# Altium schematic sheet input_filter.SchDoc(input_filter)
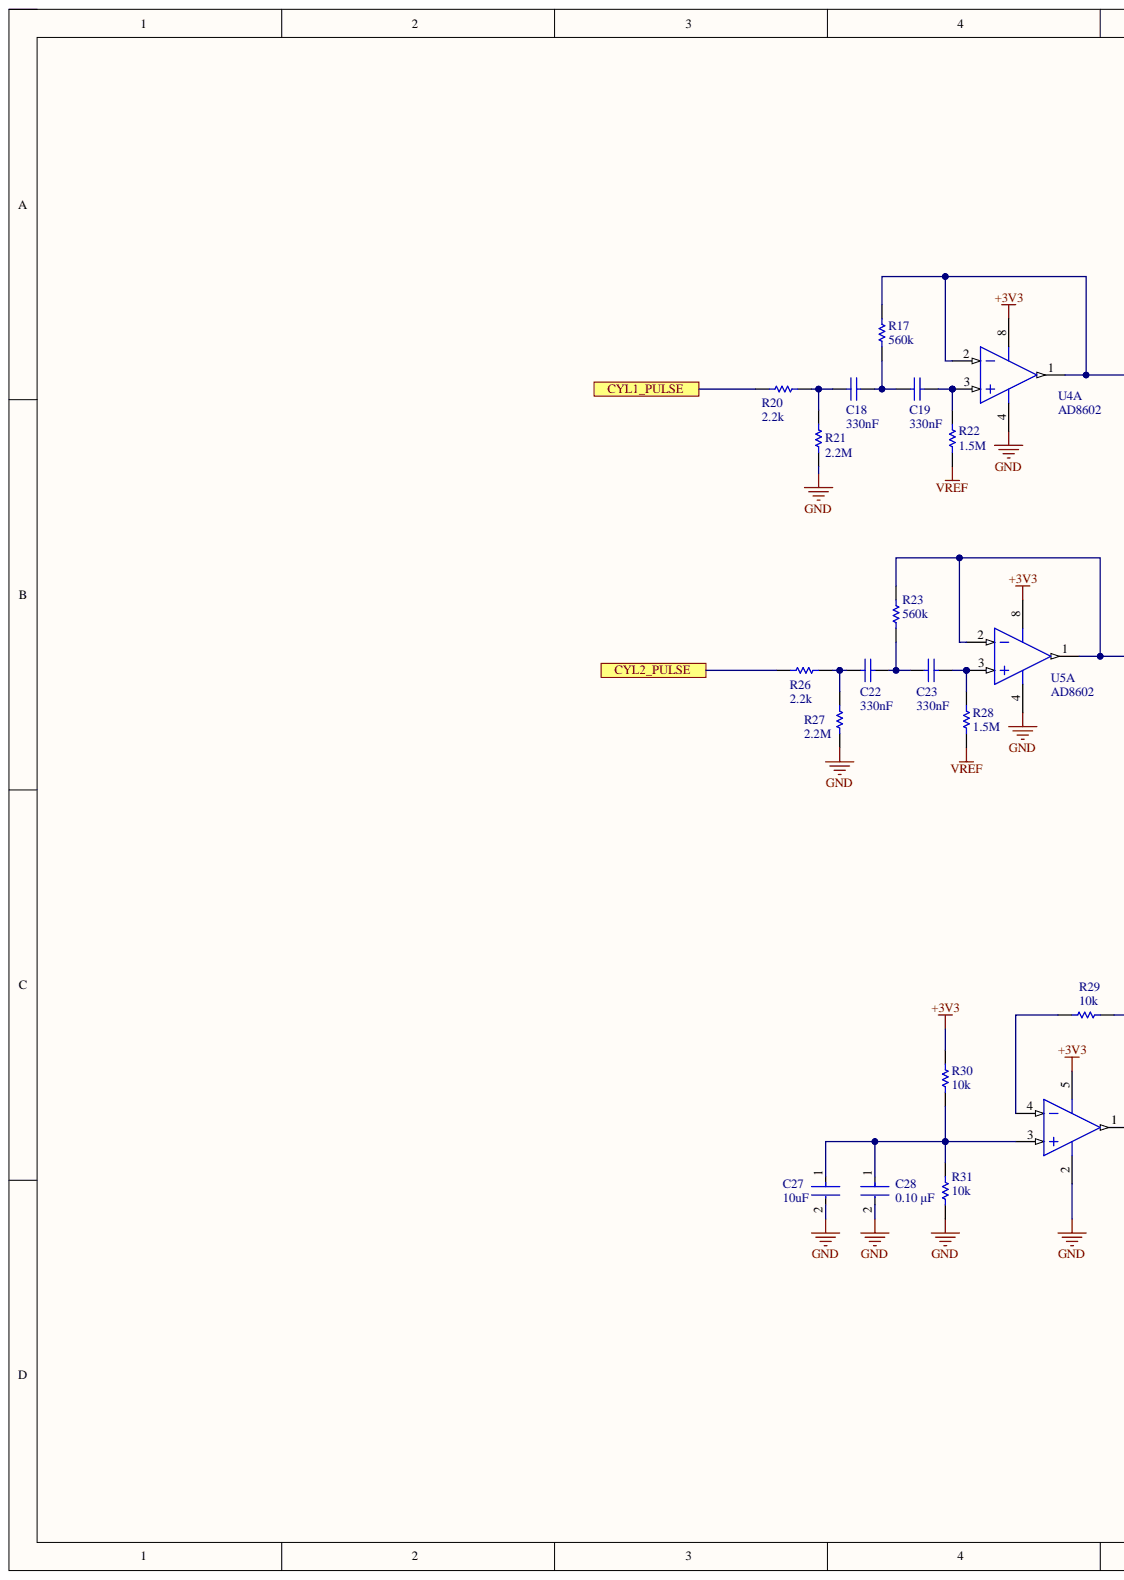
[diagram p0: input_filter.SchDoc, left]
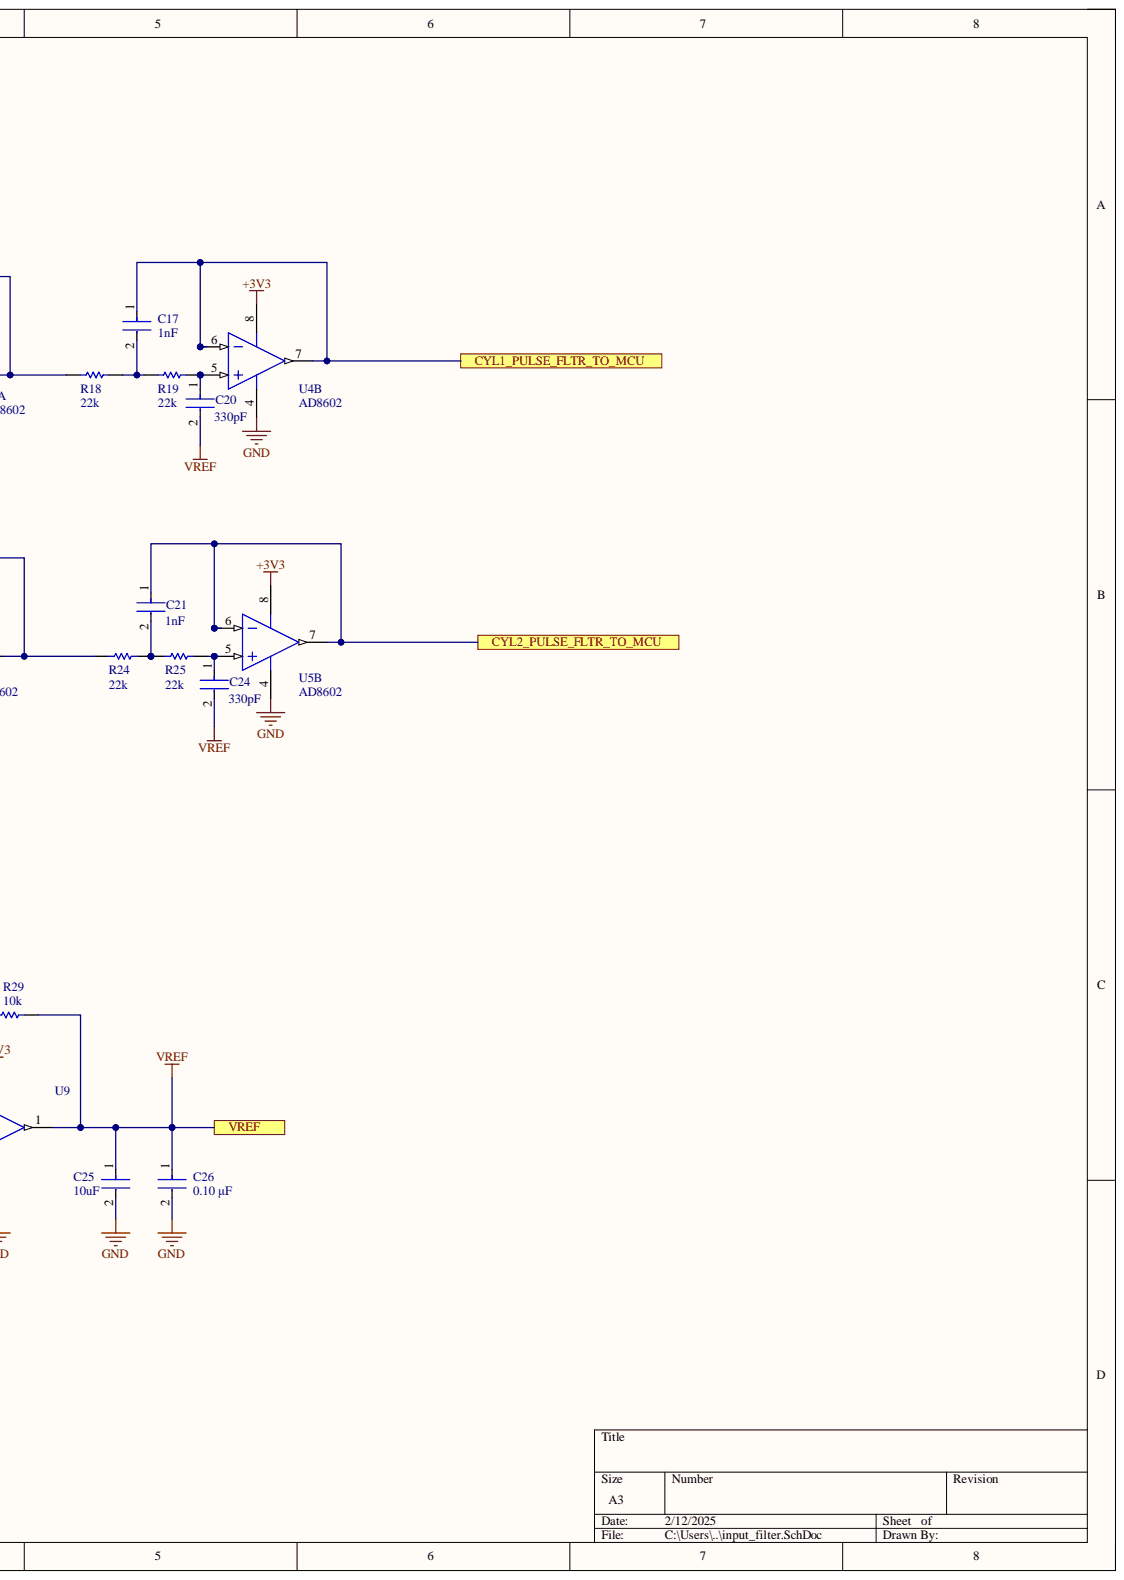
[diagram p1: input_filter.SchDoc, right]

## Parts
C17  Capacitance: 1nF  [FP-GRM155-0_05-IPC_B]  (hidden comment: GRM1555C1H102JA01D)
C18  value 330nF  [CAPC1005X55X25ML05T10]  (hidden comment: GRM155R61A334KE15D)
C19  value 330nF  [CAPC1005X55X25ML05T10]  (hidden comment: GRM155R61A334KE15D)
C20  Capacitance: 330pF  [FP-GRM155-0_05-MFG]  (hidden comment: GRM1555C1H331JA01D)
C21  Capacitance: 1nF  [FP-GRM155-0_05-IPC_B]  (hidden comment: GRM1555C1H102JA01D)
C22  value 330nF  [CAPC1005X55X25ML05T10]  (hidden comment: GRM155R61A334KE15D)
C23  value 330nF  [CAPC1005X55X25ML05T10]  (hidden comment: GRM155R61A334KE15D)
C24  Capacitance: 330pF  [FP-GRM155-0_05-MFG]  (hidden comment: GRM1555C1H331JA01D)
C25  value 10uF  Capacitance: 10uF  [FP-CL05A106MP5NUNC-MFG]  (hidden comment: CL05A106MP5NUNC)
C26  value 0.10 μF  Capacitance: 0.10 μF  [FP-C0402-BB-MFG]  (hidden comment: C0402C104K8PACTU)
C27  value 10uF  Capacitance: 10uF  [FP-CL05A106MP5NUNC-MFG]  (hidden comment: CL05A106MP5NUNC)
C28  value 0.10 μF  Capacitance: 0.10 μF  [FP-C0402-BB-MFG]  (hidden comment: C0402C104K8PACTU)
R17  value 560k  [RESC1005X40X25LL05T10]  (hidden comment: RC0402FR-07560KL)
R18  value 22k  [RESC1005X40X25NL05T10]  (hidden comment: RC0402FR-0722KL)
R19  value 22k  [RESC1005X40X25NL05T10]  (hidden comment: RC0402FR-0722KL)
R20  value 2.2k  [RESC1005X40X25NL05T10]  (hidden comment: RC0402FR-0722KL)
R21  value 2.2M  [RESC1005X40X25ML05T10]  (hidden comment: RC0402FR-072M2L)
R22  value 1.5M  [RESC1005X40X25NL05T10]  (hidden comment: RC0402FR-071M5L)
R23  value 560k  [RESC1005X40X25LL05T10]  (hidden comment: RC0402FR-07560KL)
R24  value 22k  [RESC1005X40X25NL05T10]  (hidden comment: RC0402FR-0722KL)
R25  value 22k  [RESC1005X40X25NL05T10]  (hidden comment: RC0402FR-0722KL)
R26  value 2.2k  [RESC1005X40X25NL05T10]  (hidden comment: RC0402FR-0722KL)
R27  value 2.2M  [RESC1005X40X25ML05T10]  (hidden comment: RC0402FR-072M2L)
R28  value 1.5M  [RESC1005X40X25NL05T10]  (hidden comment: RC0402FR-071M5L)
R29  value 10k  [RESC1005X40X25LL05T10]  (hidden comment: RC0402FR-0710KL)
R30  value 10k  [RESC1005X40X25LL05T10]  (hidden comment: RC0402FR-0710KL)
R31  value 10k  [RESC1005X40X25LL05T10]  (hidden comment: RC0402FR-0710KL)
U4  Generic Part Number: AD8602  [ADI-RM-8_M]  (hidden comment: AD8602DRM-REEL)  (parts A,B)
U5  Generic Part Number: AD8602  [ADI-RM-8_M]  (hidden comment: AD8602DRM-REEL)  (parts A,B)
U9  AD8031ARTZ-REEL7  [ADI-RJ-5_L]  (value not drawn)

## Ports
CYL1_PULSE, CYL1_PULSE_FLTR_TO_MCU, CYL2_PULSE, CYL2_PULSE_FLTR_TO_MCU, VREF

## Nets
+3V3: R30.1 U4.8 U5.8 U9.5
GND: C25.2 C26.2 C27.2 C28.2 R21.1 R27.1 R31.2 U4.4 U5.4 U9.2
CYL1_PULSE: R20.1 ; port CYL1_PULSE
CYL1_PULSE_FLTR_TO_MCU: C17.1 U4.6 U4.7 ; port CYL1_PULSE_FLTR_TO_MCU
CYL2_PULSE: R26.1 ; port CYL2_PULSE
CYL2_PULSE_FLTR_TO_MCU: C21.1 U5.6 U5.7 ; port CYL2_PULSE_FLTR_TO_MCU
VREF: C20.2 C24.2 C25.1 C26.1 R22.1 R28.1 R29.1 U9.1 ; port VREF
NetC17_2: C17.2 R18.2 R19.1
NetC18_1: C18.1 R20.2 R21.2
NetC18_2: C18.2 C19.1 R17.1
NetC19_2: C19.2 R22.2 U4.3
NetC20_1: C20.1 R19.2 U4.5
NetC21_2: C21.2 R24.2 R25.1
NetC22_1: C22.1 R26.2 R27.2
NetC22_2: C22.2 C23.1 R23.1
NetC23_2: C23.2 R28.2 U5.3
NetC24_1: C24.1 R25.2 U5.5
NetC27_1: C27.1 C28.1 R30.2 R31.1 U9.3
NetR17_2: R17.2 R18.1 U4.1 U4.2
NetR23_2: R23.2 R24.1 U5.1 U5.2
NetR29_2: R29.2 U9.4

## Library data of the parts
C17 C21 = CMP-2008-04254-2: Chip Multilayer Ceramic Capacitors for General Purpose, 0402, 1000pF, C0G, 30ppm/°C, 5%, 50V; Insulation Resistance: 10GR; Case Code (Imperial): 0402; Case Code (Metric): 1005; Case/Package: 0402; Component Kind: Standard; Depth: 0.5mm; Dielectric: C0G; Dielectric Material: Ceramic; Height: 0.5mm; Lead Free: Lead Free; Length: 1mm; Library Name: Altium Content Vault; Material: Ceramic; Max Operating Temperature: 125°C; Min Operating Temperature: -55°C; Mount: Surface Mount; Number of Pins: 2; Package Quantity: 1; Packaging: Tape and Reel; Radiation Hardening: No; REACH SVHC: No SVHC; Temperature Coefficient: 30ppm/°C; Termination: SMD/SMT; Thickness: 0.022inch; Tolerance: 5%; Voltage: 50V; Voltage Rating: 50V; Voltage Rating (DC): 50V; Width: 0.5mm
C18 C19 C22 C23 = CMP-2008-03929-1: Manufacturer: Murata; Component Kind: Standard; Library Name: Altium Content Vault; Part Number: GRM155R61A334KE15D
C20 C24 = CMP-06035-004830-1: Chip Multilayer Ceramic Capacitors for General Purpose, 0402, 330pF, C0G, 30ppm/°C, 5%, 50V; Insulation Resistance: 10GR; Case Code (Imperial): 0402; Case Code (Metric): 1005; Case/Package: 0402; Component Kind: Standard; Depth: 0.5mm; Dielectric: C0G; Height: 0.5mm; Lead Free: Lead Free; Length: 1mm; Library Name: Altium Content Vault; Material: Ceramic; Max Operating Temperature: 125°C; Min Operating Temperature: -55°C; Mount: Surface Mount; Number of Pins: 2; Package Quantity: 1; Packaging: Tape and Reel; Radiation Hardening: No; REACH SVHC: No SVHC; Temperature Coefficient: 30ppm/°C; Termination: SMD/SMT; Thickness: 0.022inch; Tolerance: 5%; Voltage: 50V; Voltage Rating: 50V; Voltage Rating (DC): 50V; Width: 0.5mm
C25 C27 = CMP-13271-000002-1: CAP CER 10UF 10V X5R 0402; Manufacturer: Samsung; Material: Ceramic; Temperature Coefficient: 15%; Tolerance: 20%; Automotive: No; Category: Cap; Component Kind: Standard; ComponentLink2Description: Datasheet; ComponentLink2URL: http://www.samsungsem.com/kr/support/product-search/mlcc/__icsFiles/afieldfile/2019/05/10/19-CL05A106MP5NUNC.pdf; Device Class L1: Passive Components; Device Class L2: Capacitors; Device Class L3: Ceramic Capacitors; Digikey Description: CAP CER 10UF 10V X5R 0402; Height: 0.7mm; Ipc Land Pattern Name: CAPC10050X50; Lead Free: Yes; Library Name: Altium Content Vault; Manufacturer Part Number: CL05A106MP5NUNC; Octopart: https://octopart.com/cl05a106mp5nunc-samsung-22457395; Package: CL05A106MP5NUNC; RoHS: TRUE; Temperature: -55°C to +85°C; Temperature Characteristic: X5R; Temperature Range High: +85°C; Temperature Range Low: -55°C; Voltage Rating: 10V
C26 C28 = CMP-2008-02046-2: CAP CER 0.1UF 10V X5R 0402; Manufacturer: Kemet; Material: Ceramic; Temperature Coefficient: 15%; Tolerance: 0.1; Automotive: No; Category: Cap; Component Kind: Standard; ComponentLink2Description: Datasheet; ComponentLink2URL: https://content.kemet.com/datasheets/KEM_C1002_X7R_SMD.pdf; Device Class L1: Passive Components; Device Class L2: Capacitors; Device Class L3: Ceramic Capacitors; Digikey Description: CAP CER 0.1UF 10V X5R 0402; Height: 0.55mm; Ipc Land Pattern Name: CAPC10050X50; Lead Free: Yes; Library Name: Altium Content Vault; Manufacturer Part Number: C0402C104K8PACTU; Mouser Description: Capacitor 100 nF 10 VDC 0402; Octopart: https://octopart.com/c0402c104k8pactu-kemet-140039; Package: C0402-BB; RoHS: TRUE; Temperature: -55°C to +125°C; Temperature Characteristic: X5R; Temperature Range High: +125°C; Temperature Range Low: -55°C; Voltage Rating: 10 V
R17 R23 = CMP-2002-07031-1: Manufacturer: Yageo / Phycomp; Component Kind: Standard; Library Name: Altium Content Vault; Part Number: RC0402FR-07560KL
R18-R20 R24-R26 = CMP-2002-07517-1: Manufacturer: Yageo / Phycomp; Component Kind: Standard; Library Name: Altium Content Vault; Part Number: RC0402FR-0722KL
  R18: ComponentLink1Description: New link
R21 R27 = CMP-2002-07061-1: Manufacturer: Yageo / Phycomp; Component Kind: Standard; Library Name: Altium Content Vault; Part Number: RC0402FR-072M2L
R22 R28 = CMP-2002-07106-1: Manufacturer: Yageo / Phycomp; Component Kind: Standard; Library Name: Altium Content Vault; Part Number: RC0402FR-071M5L
R29-R31 = CMP-2002-07353-1: Manufacturer: Yageo / Phycomp; Component Kind: Standard; Library Name: Altium Content Vault; Part Number: RC0402FR-0710KL
U4 U5 = CMP-0384-01796-1: Double Channel Wide Bandwidth Operational Amplifier, 8.4 MHz BW, 6 V/us SR, Industrial, 8-pin SOP (RM-8), Reel; Manufacturer: Analog Devices; Amplifiers Per Package: 2; Automotive Qualified: Yes; Input Bias Current: 200fA; Input Offset Voltage: 500uV; Iq/Amp: 1.2mA; Slew Rate: 6V/us; Small Signal Bandwidth: 8.4MHz; V Span Max: 6V; V Span Min: 2.7V; Code_JEDEC: MO-187-AA; Component Kind: Standard; ComponentLink1Description: Manufacturer URL; ComponentLink1URL: http://www.analog.com/en/index.html; ComponentLink2Description: Datasheet; ComponentLink2URL: http://www.analog.com/static/imported-files/data_sheets/AD8601_8602_8604.pdf; ComponentLink3Description: Package Specification; ComponentLink3URL: http://www.analog.com/static/imported-files/packages/PKG_PDF/MSOP%28RM%29/RM_8.pdf; DatasheetVersion: Rev. G; Library Name: Altium Content Vault; Mounting Technology: Surface Mount; PackageDescription: 8-Pin Mini Small Outline Package, Body 3 x 3 mm, Pitch 0.65 mm; PackageReference: RM-8; PartNumber: AD8602DRM-REEL
U9 = CMP-0384-01443-3: Single Channel RRIO Operational Amplifier, 80 MHz BW, 35 V/us SR, Industrial, 5-pin SOT23 (RJ-5), Reel; Generic Part Number: AD8031; Manufacturer: Analog Devices; Amplifiers Per Package: 1; Input Bias Current: 450nA; Input Offset Voltage: 1mV; Iq/Amp: 900uA; Slew Rate: 35V/us; Small Signal Bandwidth: 80MHz; V Span Max: 12V; V Span Min: 2.7V; Code_JEDEC: MO-178-AA; Component Kind: Standard; ComponentLink1Description: Manufacturer URL; ComponentLink1URL: http://www.analog.com/en/index.html; ComponentLink2Description: Datasheet; ComponentLink2URL: http://www.analog.com/static/imported-files/data_sheets/AD8031_8032.pdf; ComponentLink3Description: Package Specification; ComponentLink3URL: http://www.analog.com/static/imported-files/packages/PKG_PDF/SOT-23(RJ)/RJ_5.pdf; ComponentLink4Description: Reference Circuit; ComponentLink4URL: http://www.analog.com/en/all-operational-amplifiers-op-amps/operational-amplifiers-op-amps/ad8031/products/product.html#product-recommendations; DatasheetVersion: Rev. D; Library Name: Altium Content Vault; Mounting Technology: Surface Mount; PackageDescription: 5-Pin Small Outline Transistor Package, Body 2.9 x 1.6 mm, Pitch 0.95 mm; PackageReference: RJ-5; PackageVersion: 121608-A; Packing: Reel; PartNumber: AD8031ARTZ-REEL7; RevisionNote: Reference Circuit URL fixed
